# Altium schematic sheet Carte_TryOhm.SchDoc(Carte_TryOhm)
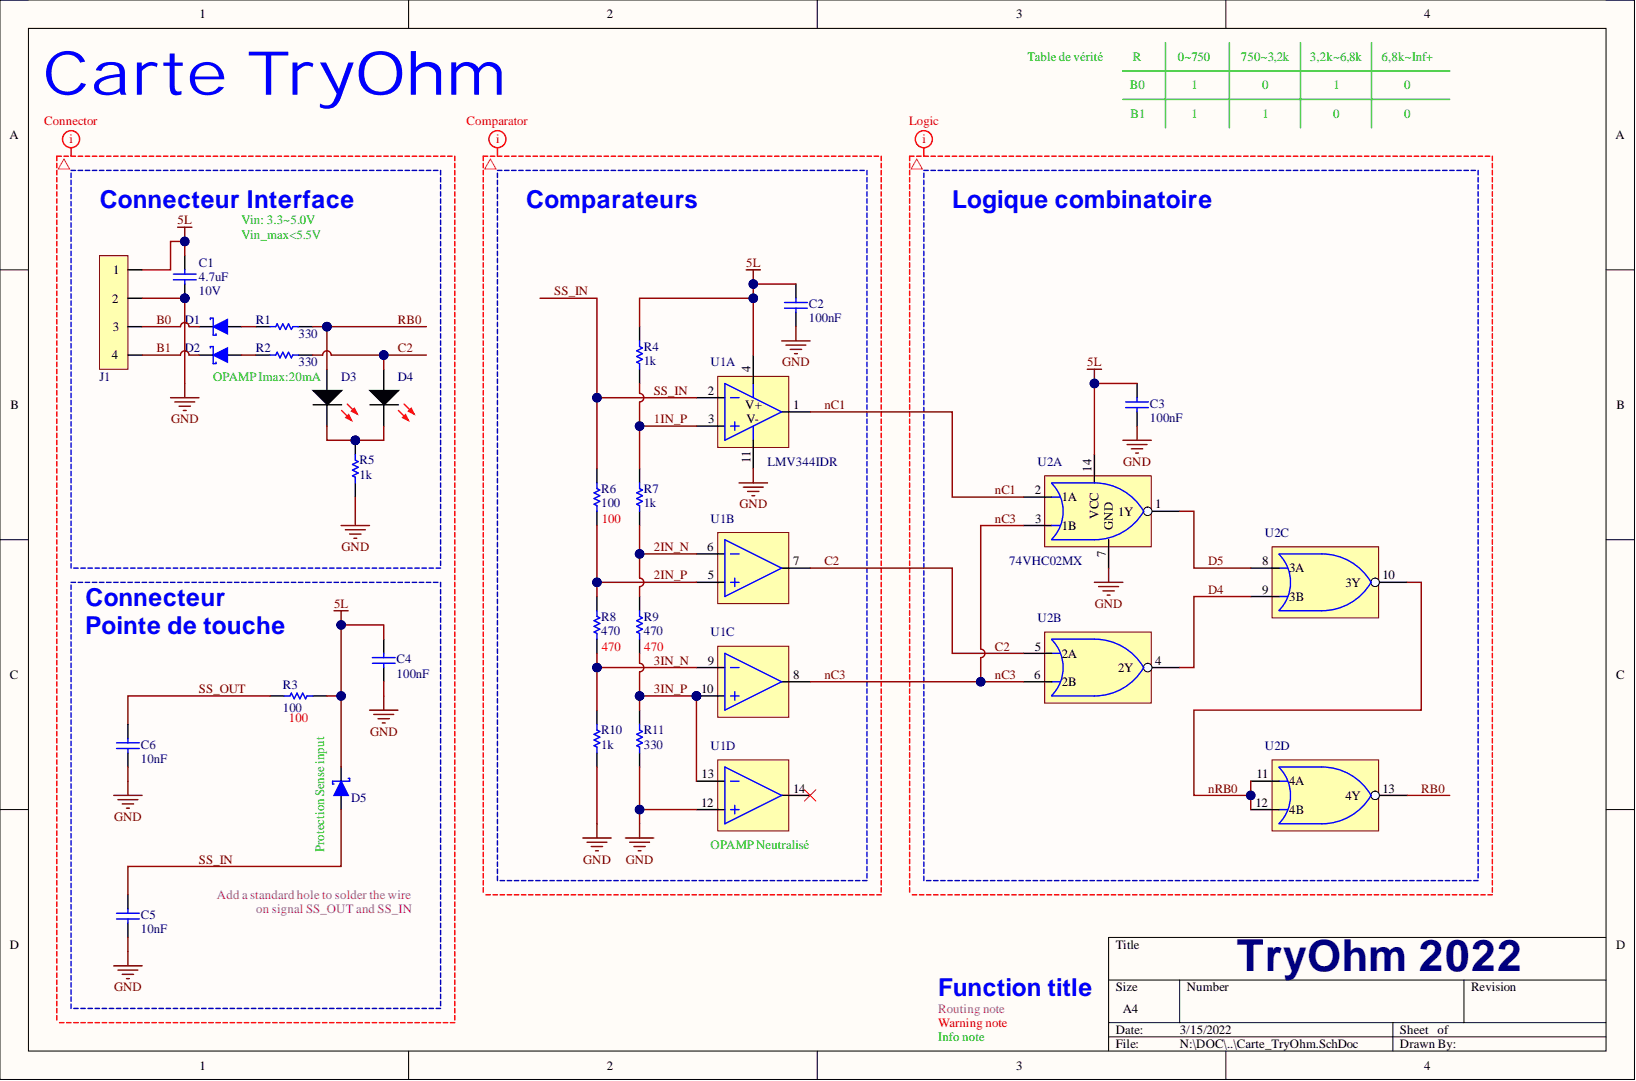
[diagram p0: Carte_TryOhm.SchDoc]

## Parts
C1  4.7uF  RatedVoltage: 10V  [WCAP-CSGP_0603, 1.6x0.8x0.8]
C2  100nF  [WCAP-CSGP_0603, 1.6x0.8x0.8]
C3  100nF  [WCAP-CSGP_0603, 1.6x0.8x0.8]
C4  100nF  [WCAP-CSGP_0603, 1.6x0.8x0.8]
C5  10nF  [WCAP-CSGP_0603, 1.6x0.8x0.8]
C6  10nF  [WCAP-CSGP_0603, 1.6x0.8x0.8]
D1  RBR3MM40ATFTR  [SOD-123FL]  (value not drawn)
D2  RBR3MM40ATFTR  [SOD-123FL]  (value not drawn)
D3  150060RS75000  [SMCW_0603]  (value not drawn)
D4  150060RS75000  [SMCW_0603]  (value not drawn)
D5  RBR3MM40ATFTR  [SOD-123FL]  (value not drawn)
J1  22-03-2041  [22-03-2041]  (value not drawn)
R1  value 330  [RESC1608X06N]  (hidden comment: RC0603FR-07330RL)
R2  value 330  [RESC1608X06N]  (hidden comment: RC0603FR-07330RL)
R3  value 100  [RESC1608X06N]  (hidden comment: RC0603FR-07100RL)
R4  value 1k  [RESC1608X06N]  (hidden comment: RC0603FR-071KL)
R5  value 1k  [RESC1608X06N]  (hidden comment: RC0603FR-071KL)
R6  value 100  [RESC1608X06N]  (hidden comment: RC0603FR-07100RL)
R7  value 1k  [RESC1608X06N]  (hidden comment: RC0603FR-071KL)
R8  value 470  [RESC1608X06N]  (hidden comment: RC0603FR-07470RL)
R9  value 470  [RESC1608X06N]  (hidden comment: RC0603FR-07470RL)
R10  value 1k  [RESC1608X06N]  (hidden comment: RC0603FR-071KL)
R11  value 330  [RESC1608X06N]  (hidden comment: RC0603FR-07330RL)
U1  LMV344IDR  [SOIC127P600X175-14N]  (parts A,B,C,D)
U2  74VHC02MX  [SOIC127P600X175-14N]  (parts A,B,C,D)

## Nets
5L: C1.1 C2.1 C3.1 C4.1 D5.2 J1.1 R3.1 R4.1 U1.4(V+) U2.14(VCC)
GND: C1.2 C2.2 C3.2 C4.2 C5.1 C6.1 J1.2 R5.1 R10.2 R11.2 U1.11(V-) U1.12 U2.7(GND)
1IN_P: R4.2 R7.1 U1.3
2IN_N: R7.2 R9.1 U1.6
2IN_P: R6.2 R8.1 U1.5
3IN_N: R8.2 R10.1 U1.9
3IN_P: R9.2 R11.1 U1.10 U1.13
B0: D1.2 J1.3
B1: D2.2 J1.4
C2: D4.2 R2.1 U1.7 U2.5(2A)
D4: U2.4(2Y) U2.9(3B)
D5: U2.1(1Y) U2.8(3A)
nC1: U1.1 U2.2(1A)
nC3: U1.8 U2.3(1B) U2.6(2B)
nRB0: U2.10(3Y) U2.11(4A) U2.12(4B)
RB0: D3.2 R1.1 U2.13(4Y)
SS_IN: C5.2 D5.1 R6.1 U1.2
SS_OUT: C6.2 R3.2
NetD1_1: D1.1 R1.2
NetD2_1: D2.1 R2.2
NetD3_1: D3.1 D4.1 R5.2

Not connected: U1 14

## Library data of the parts
C1 = WCAP-CSGP, 885012106012: CAP CER 4.7UF 10V X5R 0603; Manufacturer: Wurth Elektronik; CeramicType: X5R; Component Kind: Standard; ComponentLink1Description: Datasheet; ComponentLink1URL: http://katalog.we-online.com/pbs/datasheet/885012106012.pdf; Manufacturer Part Number: 885012106012; Size: 0603; Supplier 1: Farnell; Supplier 2: JLCPCB; Supplier Footprint 2: 0603; Supplier Part Number 1: 2495148; Supplier Part Number 2: C19666; Value: 4.7uF; Library Name: Condensateur.IntLib
C2-C4 = WCAP-CSGP, 885012206095: CAP CER 100nF 50V X7R 0603; Manufacturer: Wurth Elektronik; CeramicType: X7R; Component Kind: Standard; ComponentLink1Description: Datasheet; ComponentLink1URL: http://katalog.we-online.com/pbs/datasheet/885012206095.pdf; Manufacturer Part Number: 885012206095; RatedVoltage: 50V; Size: 0603; Supplier 1: Farnell; Supplier 2: JLCPCB; Supplier Footprint 2: 0603; Supplier Part Number 1: 2495187; Supplier Part Number 2: C14663; Value: 100nF; Library Name: Condensateur.IntLib
C5 C6 = WCAP-CSGP, 885012206089: CAP CER 10nF 50V X7R 0603; Manufacturer: Wurth Elektronik; CeramicType: X7R; Component Kind: Standard; ComponentLink1Description: Datasheet; ComponentLink1URL: http://katalog.we-online.com/pbs/datasheet/885012206089.pdf; Manufacturer Part Number: 885012206089; RatedVoltage: 50V; Size: 0603; Supplier 1: Farnell; Supplier 2: JLCPCB; Supplier Footprint 2: 0603; Supplier Part Number 1: 2495182; Supplier Part Number 2: C57112; Value: 10nF; Library Name: Condensateur.IntLib
D1 D2 D5 = RBR3MM40ATFTR: DIODE SCHOTTKY 40V 3A SOD123-FL; Manufacturer: ROHM; Component Kind: Standard; ComponentLink1Description: Datasheet; ComponentLink1URL: http://www.farnell.com/datasheets/2067190.pdf; ComponentLink2Description: Manufacturer; ComponentLink2URL: http://www.rohm.com/web/global/products/-/product/RBR3MM40ATF; ContinuousForwardCurrent: 3A; Library Name: Diode.IntLib; Manufacturer Part Number: RBR3MM40ATFTR; Supplier 1: Farnell; Supplier 2: JLCPCB; Supplier Footprint 2: SOD-123; Supplier Part Number 1: 2581593; Supplier Part Number 2: C8598; Type: Schottky; Value: 40V
D3 D4 = WL-SMCW 0603  150060RS75000: SMD mono-color Chip LED, WL-SMCW, Red; Manufacturer: Wurth Elektronik; Value: Rouge; Component Kind: Standard; ComponentLink1Description: Datasheet; ComponentLink1URL: http://katalog.we-online.com/led/datasheet/150060RS75000.pdf; Library Name: Opto.IntLib; Manufacturer Part Number: 150060RS75000; Supplier 1: Farnell; Supplier 2: JLCPCB; Supplier Footprint 2: LED_0603; Supplier Part Number 1: 2322071; Supplier Part Number 2: C72044
J1 = 22-03-2041: CONN HEADER VERT 4POS 2.54MM; Manufacturer: MOLEX; Component Kind: Standard; ComponentLink1Description: Datasheet; ComponentLink1URL: https://www.molex.com/pdm_docs/sd/022032041_sd.pdf; Manufacturer Part Number: 22-03-2041; Supplier 1: Farnell; Supplier Part Number 1: 9731091; Library Name: Connecteurs.IntLib
R1-R3 R6 R8 R9 R11 = RC0603FR-07330RL: RES SMD 330 OHM 1% 1/10W 0603; Manufacturer: Yageo; Power: 100mW; Vmax: 50V; Component Kind: Standard; ComponentLink1Description: Manufacturer; ComponentLink1URL: http://www.yageo.com/NewPortal/_en/index.jsp; ComponentLink2Description: Datasheet; ComponentLink2URL: http://www.farnell.com/datasheets/2167052.pdf; Precision: ±1%; Supplier 1: Farnell; Supplier 2: JLCPCB; Supplier Footprint 2: 0603; Supplier Part Number 1: 9238425; Type: Thick Film; Library Name: Resistance.IntLib
  R1 R2 R11: Manufacturer Part Number: RC0603FR-07330RL; Supplier Part Number 2: C23138
  R3 R6: Manufacturer Part Number: RC0603FR-07100RL; Supplier Part Number 2: C22775
  R8 R9: Manufacturer Part Number: RC0603FR-07470RL; Supplier Part Number 2: C23179
R4 R5 R7 R10 = RC0603FR-071KL: RES SMD 1K OHM 1% 1/10W 0603; Manufacturer: Yageo; Power: 100mW; Vmax: 50V; Component Kind: Standard; ComponentLink1Description: Manufacturer; ComponentLink1URL: http://www.yageo.com/NewPortal/_en/index.jsp; ComponentLink2Description: Datasheet; ComponentLink2URL: http://www.farnell.com/datasheets/2167052.pdf; Manufacturer Part Number: RC0603FR-071KL; Precision: ±1%; Supplier 1: Farnell; Supplier 2: JLCPCB; Supplier Footprint 2: 0603; Supplier Part Number 1: 9238484; Supplier Part Number 2: C21190; Type: Thick Film; Library Name: Resistance.IntLib
U1 = LMV344IDR: IC OPAMP GP 4 CIRCUIT 14SOIC; Manufacturer: TEXAS INSTRUMENTS; Component Kind: Standard; ComponentLink1Description: Datasheet; ComponentLink1URL: https://www.ti.com/lit/ds/symlink/lmv341.pdf; Library Name: CI.IntLib; Manufacturer Part Number: LMV344IDR; Supplier 1: Farnell; Supplier 2: JLCPCB; Supplier Part Number 1: 3117230; Supplier Part Number 2: C181501
U2 = 74VHC02MX: IC GATE NOR 4CH 2-INP 14SOIC; Manufacturer: ONSEMI; Component Kind: Standard; ComponentLink1Description: Datasheet; ComponentLink1URL: https://www.onsemi.com/pdf/datasheet/74vhc02-d.pdf; Manufacturer Part Number: 74VHC02MX; Supplier 1: Farnell; Supplier 2: JLCPCB; Supplier Part Number 1: 2453074; Supplier Part Number 2: C238963; Library Name: CI.IntLib
